# Altium schematic sheet LIDO-HW.200.MCU_Peripherals_2.SchDoc(LIDO-HW.200.MCU_Peripherals_2)
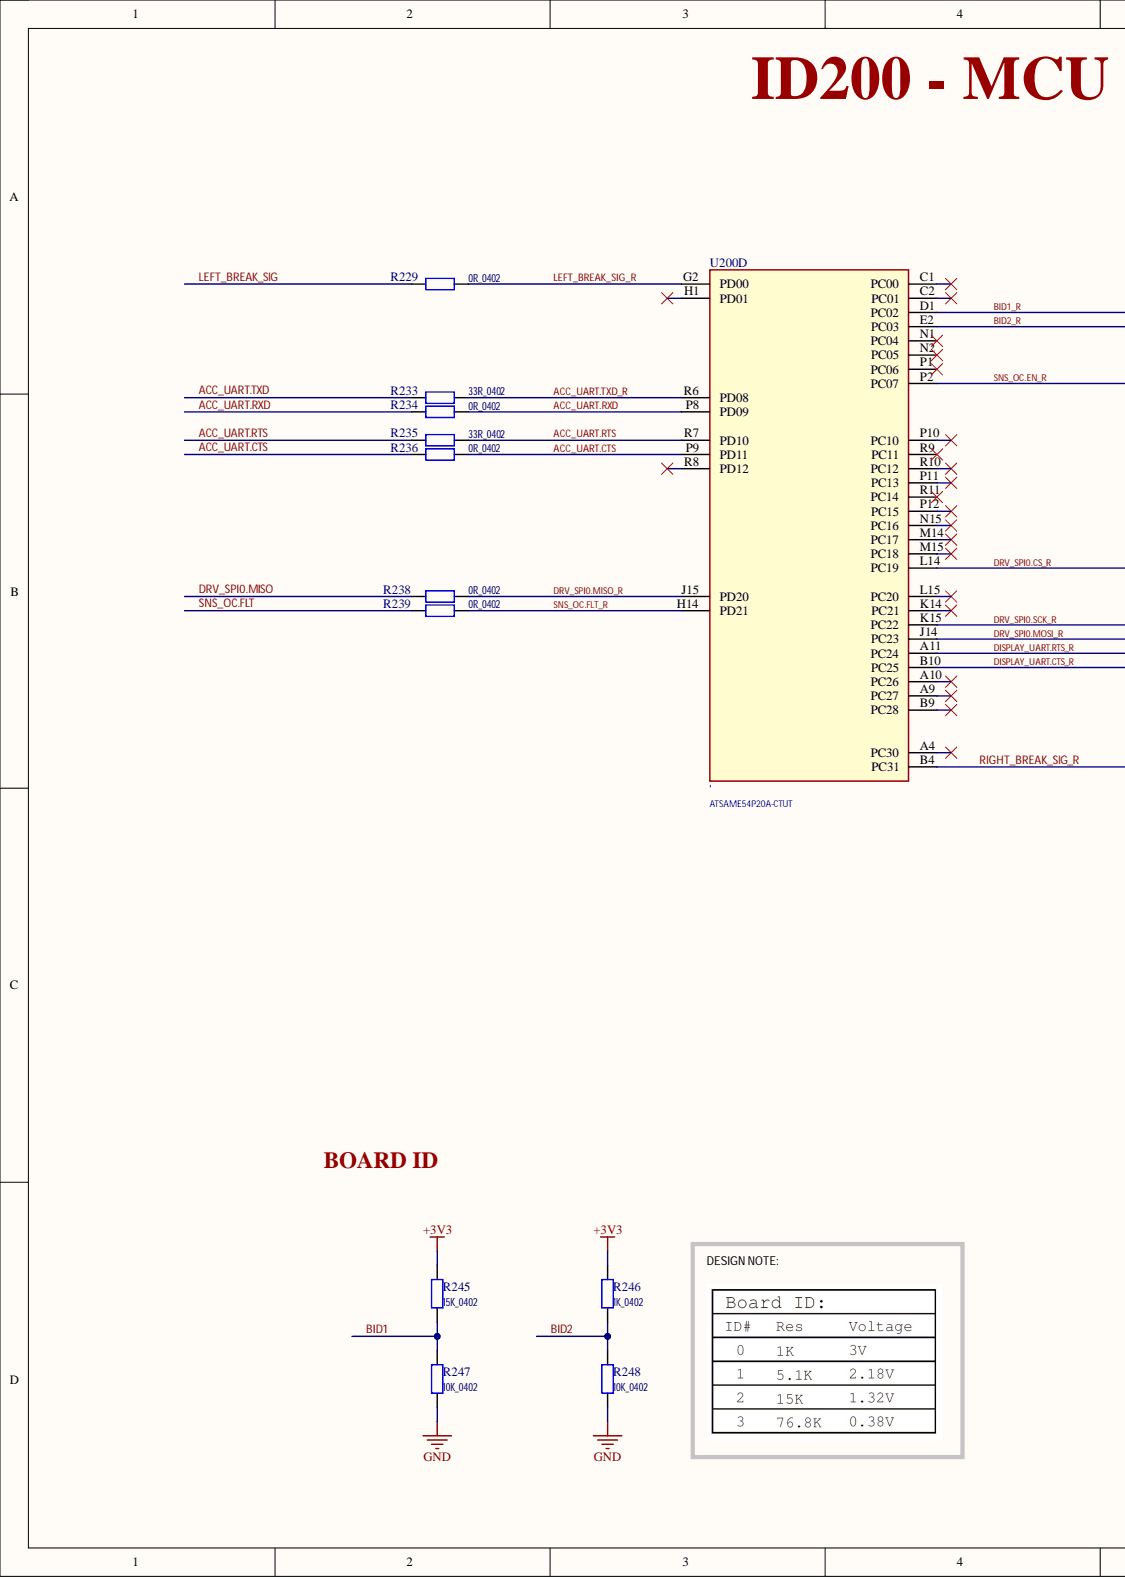
[diagram p0: LIDO-HW.200.MCU_Peripherals_2.SchDoc, left]
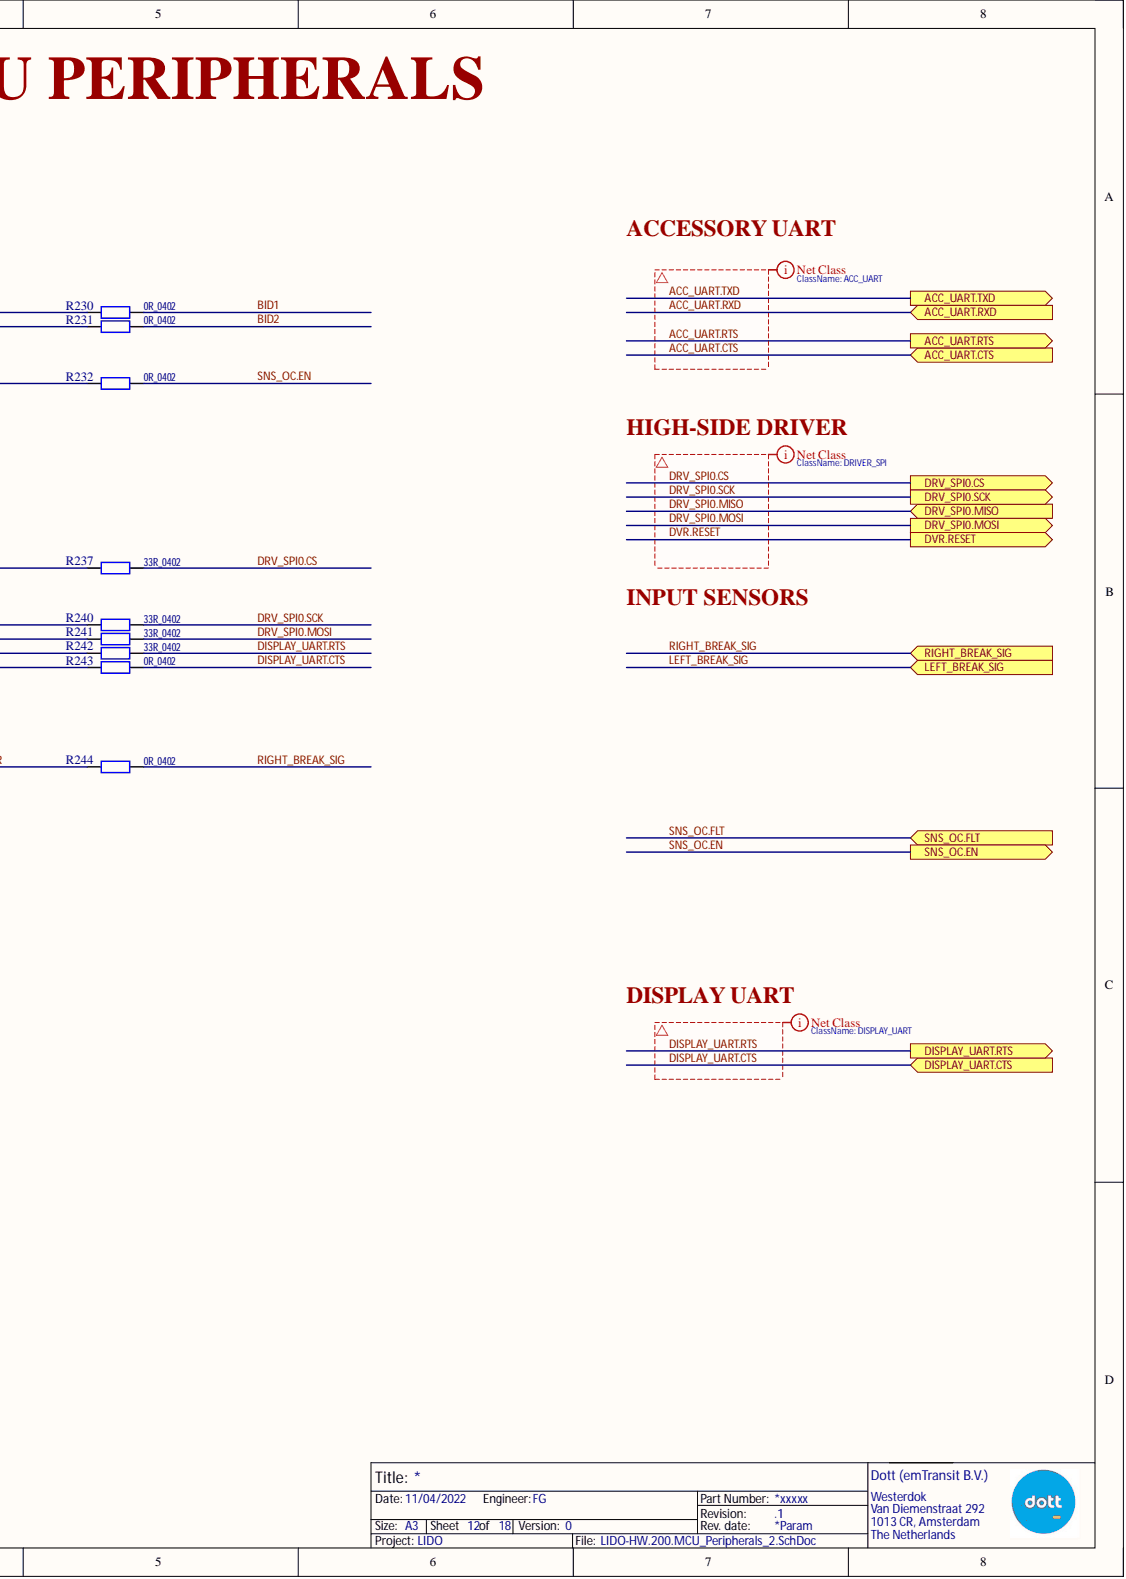
[diagram p1: LIDO-HW.200.MCU_Peripherals_2.SchDoc, right]

## Parts
R229  0R_0402
R230  0R_0402
R231  0R_0402
R232  0R_0402
R233  33R_0402
R234  0R_0402
R235  33R_0402
R236  0R_0402
R237  33R_0402
R238  0R_0402
R239  0R_0402
R240  33R_0402
R241  33R_0402
R242  33R_0402
R243  0R_0402
R244  0R_0402
R245  15K_0402
R246  1K_0402
R247  10K_0402
R248  10K_0402
U200  '  (part D)

## Ports
ACC_UART.CTS, ACC_UART.RTS, ACC_UART.RXD, ACC_UART.TXD, DISPLAY_UART.CTS, DISPLAY_UART.RTS, DRV_SPI0.CS, DRV_SPI0.MISO, DRV_SPI0.MOSI, DRV_SPI0.SCK, DVR.RESET, LEFT_BREAK_SIG, RIGHT_BREAK_SIG, SNS_OC.EN, SNS_OC.FLT

## Nets
+3V3: R245.2 R246.2
GND: R247.1 R248.1
ACC_UART.CTS: R236.1 R236.2 U200.P9(PD11) ; port ACC_UART.CTS
ACC_UART.RTS: R235.1 R235.2 U200.R7(PD10) ; port ACC_UART.RTS
ACC_UART.RXD: R234.1 R234.2 U200.P8(PD09) ; port ACC_UART.RXD
ACC_UART.TXD: R233.2 ; port ACC_UART.TXD
ACC_UART.TXD_R: R233.1 U200.R6(PD08)
BID1: R230.1 R245.1 R247.2
BID1_R: R230.2 U200.D1(PC02)
BID2: R231.1 R246.1 R248.2
BID2_R: R231.2 U200.E2(PC03)
DISPLAY_UART.CTS: R243.1 ; port DISPLAY_UART.CTS
DISPLAY_UART.CTS_R: R243.2 U200.B10(PC25)
DISPLAY_UART.RTS: R242.1 ; port DISPLAY_UART.RTS
DISPLAY_UART.RTS_R: R242.2 U200.A11(PC24)
DRV_SPI0.CS: R237.1 ; port DRV_SPI0.CS
DRV_SPI0.CS_R: R237.2 U200.L14(PC19)
DRV_SPI0.MISO: R238.1 ; port DRV_SPI0.MISO
DRV_SPI0.MISO_R: R238.2 U200.J15(PD20)
DRV_SPI0.MOSI: R241.1 ; port DRV_SPI0.MOSI
DRV_SPI0.MOSI_R: R241.2 U200.J14(PC23)
DRV_SPI0.SCK: R240.1 ; port DRV_SPI0.SCK
DRV_SPI0.SCK_R: R240.2 U200.K15(PC22)
LEFT_BREAK_SIG: R229.2 ; port LEFT_BREAK_SIG
LEFT_BREAK_SIG_R: R229.1 U200.G2(PD00)
RIGHT_BREAK_SIG: R244.1 ; port RIGHT_BREAK_SIG
RIGHT_BREAK_SIG_R: R244.2 U200.B4(PC31)
SNS_OC.EN: R232.1 ; port SNS_OC.EN
SNS_OC.EN_R: R232.2 U200.P2(PC07)
SNS_OC.FLT: R239.1 ; port SNS_OC.FLT
SNS_OC.FLT_R: R239.2 U200.H14(PD21)
DVR.RESET: ; port DVR.RESET

Not connected: U200 A4(PC30) A9(PC27) A10(PC26) B9(PC28) C1(PC00) C2(PC01) H1(PD01) K14(PC21) L15(PC20) M14(PC17) M15(PC18) N1(PC04) N2(PC05) N15(PC16) P1(PC06) P10(PC10) P11(PC13) P12(PC15) R8(PD12) R9(PC11) R10(PC12) R11(PC14)

## Library data of the parts
R229-R248 = Resistor: Resistor Symbol; Component Kind: Standard; Library Name: 02_Resistors.SchLib
U200 = ATMEL_ATSAME54P20A-CT_A: 32-bit ARM® Cortex®-M4F MCUs with 1 Msps 12-bit ADC,  QSPI, USB, Ethernet, and PTC; Component Kind: Standard; Library Name: LIDO_Lib.SchLib
